# Altium schematic sheet Accel_3_click_v100.SchDoc
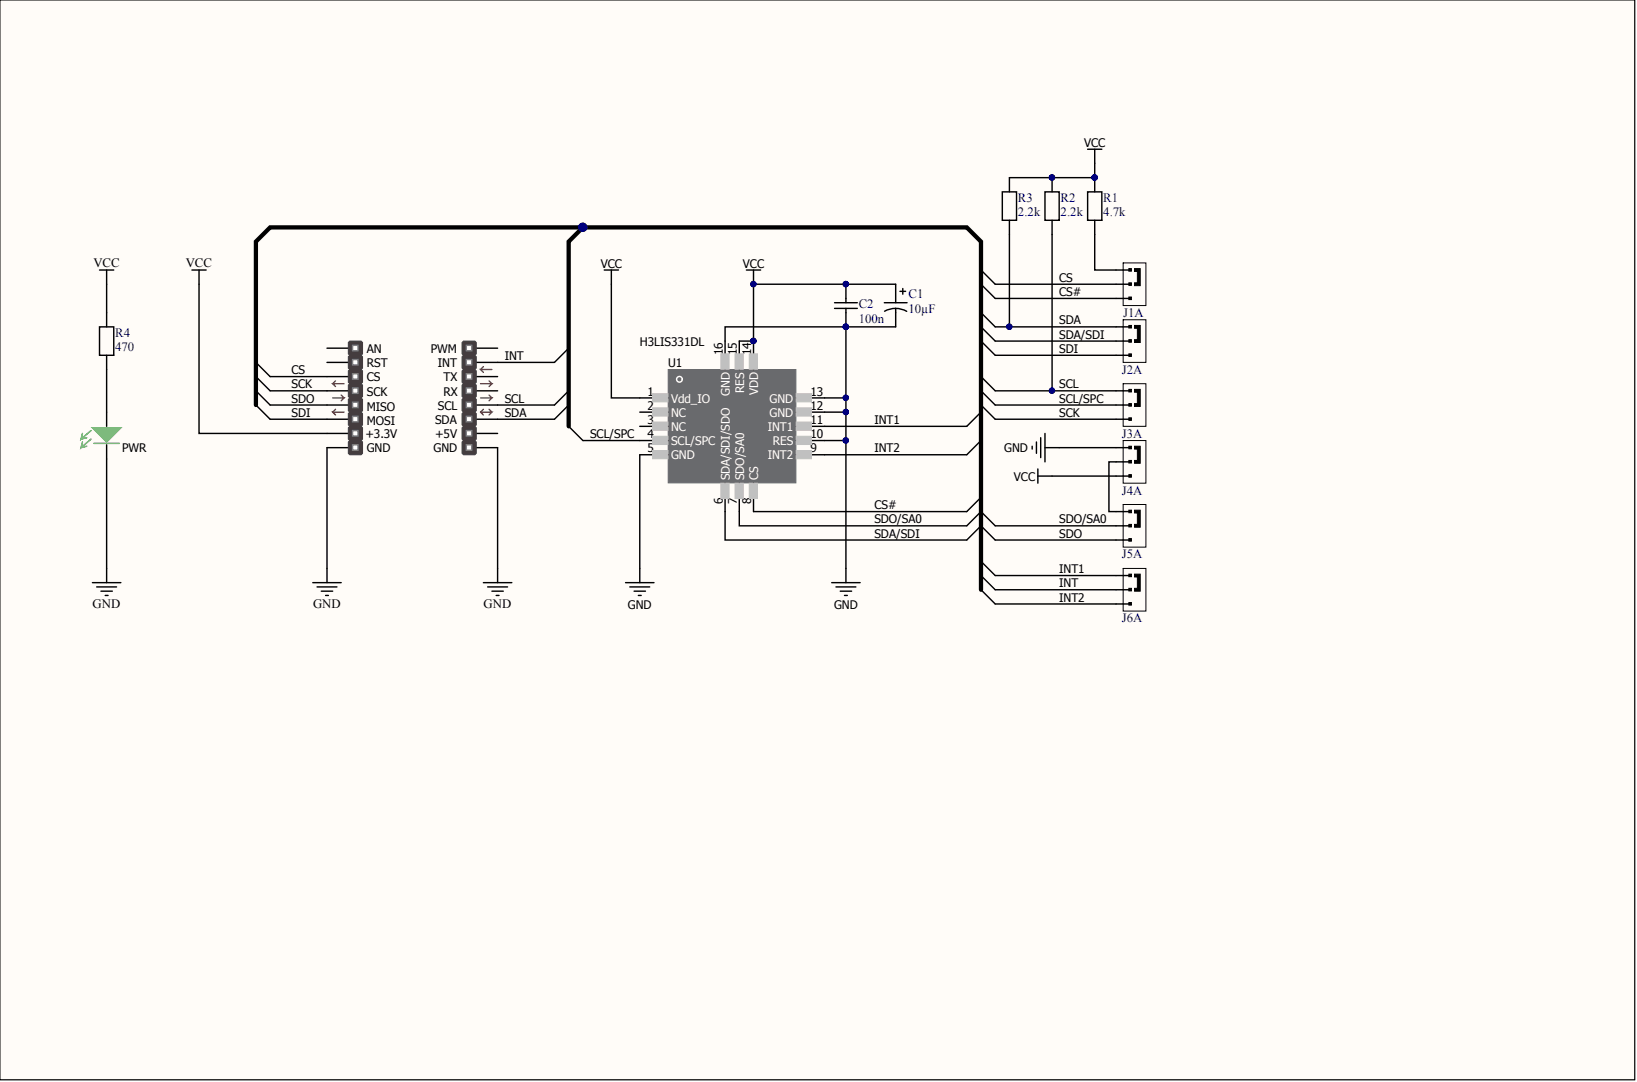
[diagram p0: Accel_3_click_v100.SchDoc]

## Parts
C1  10µF  [CAPMP3528X210N-TypeB-NoOverlay]
C2  100n  [CAPC2013X100N-0805-NoOverlay]
J1  (no value)  [0805 DUAL v2]  (part A)
J2  0R  [0805 DUAL]  (value not drawn)  (part A)
J3  0R  [0805 DUAL]  (value not drawn)  (part A)
J4  0R  [0805 DUAL v2]  (value not drawn)  (part A)
J5  0R  [0805 DUAL]  (value not drawn)  (part A)
J6  0R  [0805 DUAL v2]  (value not drawn)  (part A)
MIKROBUS_DEVICE_CONN.1  MIKROBUS DEVICE CONN.  [MIKRO_BUS_MINI]  (value not drawn)
PWR  LED  [0805 - LED]  (value not drawn)
R1  4.7k  [RESC2013X38N-0805-NOOVERLAY]
R2  2.2k  [RESC2013X38N-0805-NOOVERLAY]
R3  2.2k  [RESC2013X38N-0805-NOOVERLAY]
R4  470  [RESC2013X38N-0805-NOOVERLAY]
U1  H3LIS331DL  [TFLGA 16L]

## Nets
GND: C1.2 C2.2 J4.1 MIKROBUS_DEVICE_CONN.1.8(GND) MIKROBUS_DEVICE_CONN.1.9(GND) PWR.2 U1.5(GND) U1.10(RES) U1.12(GND) U1.13(GND) U1.16(GND)
VCC: C1.1 C2.1 J4.3 MIKROBUS_DEVICE_CONN.1.7(+3.3V) R1.2 R2.2 R3.2 R4.2 U1.1(Vdd_IO) U1.14(VDD) U1.15(RES)
CS: J1.2 MIKROBUS_DEVICE_CONN.1.3(CS)
CS#: J1.3 U1.8(CS)
INT: J6.2 MIKROBUS_DEVICE_CONN.1.15(INT)
INT1: J6.1 U1.11(INT1)
INT2: J6.3 U1.9(INT2)
SCK: J3.3 MIKROBUS_DEVICE_CONN.1.4(SCK)
SCL: J3.1 MIKROBUS_DEVICE_CONN.1.12(SCL) R2.1
SCL/SPC: J3.2 U1.4(SCL/SPC)
SDA: J2.1 MIKROBUS_DEVICE_CONN.1.11(SDA) R3.1
SDA/SDI: J2.2 U1.6(SDA/SDI/SDO)
SDI: J2.3 MIKROBUS_DEVICE_CONN.1.6(MOSI)
SDO: J5.3 MIKROBUS_DEVICE_CONN.1.5(MISO)
SDO/SA0: J5.2 U1.7(SDO/SA0)
NetJ1_1: J1.1 R1.1
NetJ4_2: J4.2 J5.1
NetPWR_1: PWR.1 R4.1
N00007:

Not connected: MIKROBUS_DEVICE_CONN.1 1(AN) 2(RST) 10(+5V) 13(RX) 14(TX) 16(PWM); U1 2(NC) 3(NC)

## Library data of the parts
C1 = 0100000001882: CAP TANT 10UF 16V 10% 1210; Manufacturer: AVX Corporation; Manufacturer Part Number: TPSB106K016R0800; Assembly: SMD; Database Table Name: capacitors; id: 158; item_id: 452; Barcode: 0100000001882; Capacitance: 10µF; Category: Capacitors; Component Kind: Standard; Datasheet URL: http://www.avx.com/docs/Catalogs/tps.pdf; Package Case: 1210 (3528 Metric); Part type: Capacitors1; Tolerance: ±10%; Voltage Rated: 16V; Library Name: erp-baza.SVNDbLib
C2 = 0100000001868: Manufacturer: Yageo; Manufacturer Part Number: 2238 580 15649; Assembly: SMD; Database Table Name: capacitors; id: 137; item_id: 450; Temperature Coefficient: X7R; Tolerance: 10%; Voltage Rated: 50; Barcode: 0100000001868; Capacitance: 100n; Category: Capacitors; Component Kind: Standard; Package Case: 0805; Part type: Capacitors1; Library Name: erp-baza.SVNDbLib
J1-J6 = JUMPER2 SMD: SMD Jumper, two state; Component Kind: Standard; SMD: Yes
  J1: Library Name: AMBIENT_click_v100.SCHLIB
  J2-J6: Library Name: Hi-G Accel_click_v100.SCHLIB
MIKROBUS_DEVICE_CONN.1 = MIKROBUS DEVICE CONN.: mikroBUS Target Header; Component Kind: Standard; Library Name: SCH_Rega.SchLib
R1 = 0100000002056: RES SMD 4.7K OHM 1% 1/8W 0805; Manufacturer: Yageo; Manufacturer Part Number: RC0805FR-074K7L; Database Table Name: resistors; id: 222; item_id: 470; Power: 0.125W, 1/8W; Temperature Coefficient: ±100ppm/°C; Assembly: SMD; Barcode: 0100000002056; Category: Resistors; Component Kind: Standard; Datasheet URL: http://www.yageo.com/NewPortal/yageodocoutput?fileName=/pdf/R-Chip/PYu-RC_Group_51_RoHS_L_3.pdf; Package Case: 0805 (2012 Metric); Part Type: Resistors1; Resistance: 4.7k; Tolerance: ±1%; Library Name: erp-baza.SVNDbLib
R2 R3 = 0100000002025: RES SMD 2.2K OHM 1% 1/8W 0805; Manufacturer: Yageo; Manufacturer Part Number: RC0805FR-072K2L; Database Table Name: resistors; id: 225; item_id: 467; Power: 0.125W, 1/8W; Temperature Coefficient: ±100ppm/°C; Assembly: SMD; Barcode: 0100000002025; Category: Resistors; Component Kind: Standard; Datasheet URL: http://www.yageo.com/NewPortal/yageodocoutput?fileName=/pdf/R-Chip/PYu-RC_Group_51_RoHS_L_3.pdf; Package Case: 0805 (2012 Metric); Part Type: Resistors1; Resistance: 2.2k; Tolerance: ±1%; Library Name: erp-baza.SVNDbLib
R4 = 0100000007921: RES SMD 470 OHM 1% 1/8W 0805; Manufacturer: Yageo; Manufacturer Part Number: RC0805FR-07470RL; Database Table Name: resistors; id: 208; item_id: 1082; Power: 0.125W, 1/8W; Temperature Coefficient: ±100ppm/°C; Assembly: SMD; Barcode: 0100000007921; Category: Resistors; Component Kind: Standard; Datasheet URL: http://www.yageo.com/NewPortal/yageodocoutput?fileName=/pdf/R-Chip/PYu-RC_Group_51_RoHS_L_3.pdf; Package Case: 0805 (2012 Metric); Part Type: Resistors1; Resistance: 470; Tolerance: ±1%; Library Name: erp-baza.SVNDbLib
PWR = LED: Component Kind: Standard; SMD: Yes; Library Name: template_click_v100.SCHLIB
U1 = H3LIS331DL: Component Kind: Standard; Description: H3LIS331DL; SMD: Yes; Library Name: AMBIENT_click_v100.SCHLIB
